# Altium schematic sheet Log and Anti-Log.SchDoc
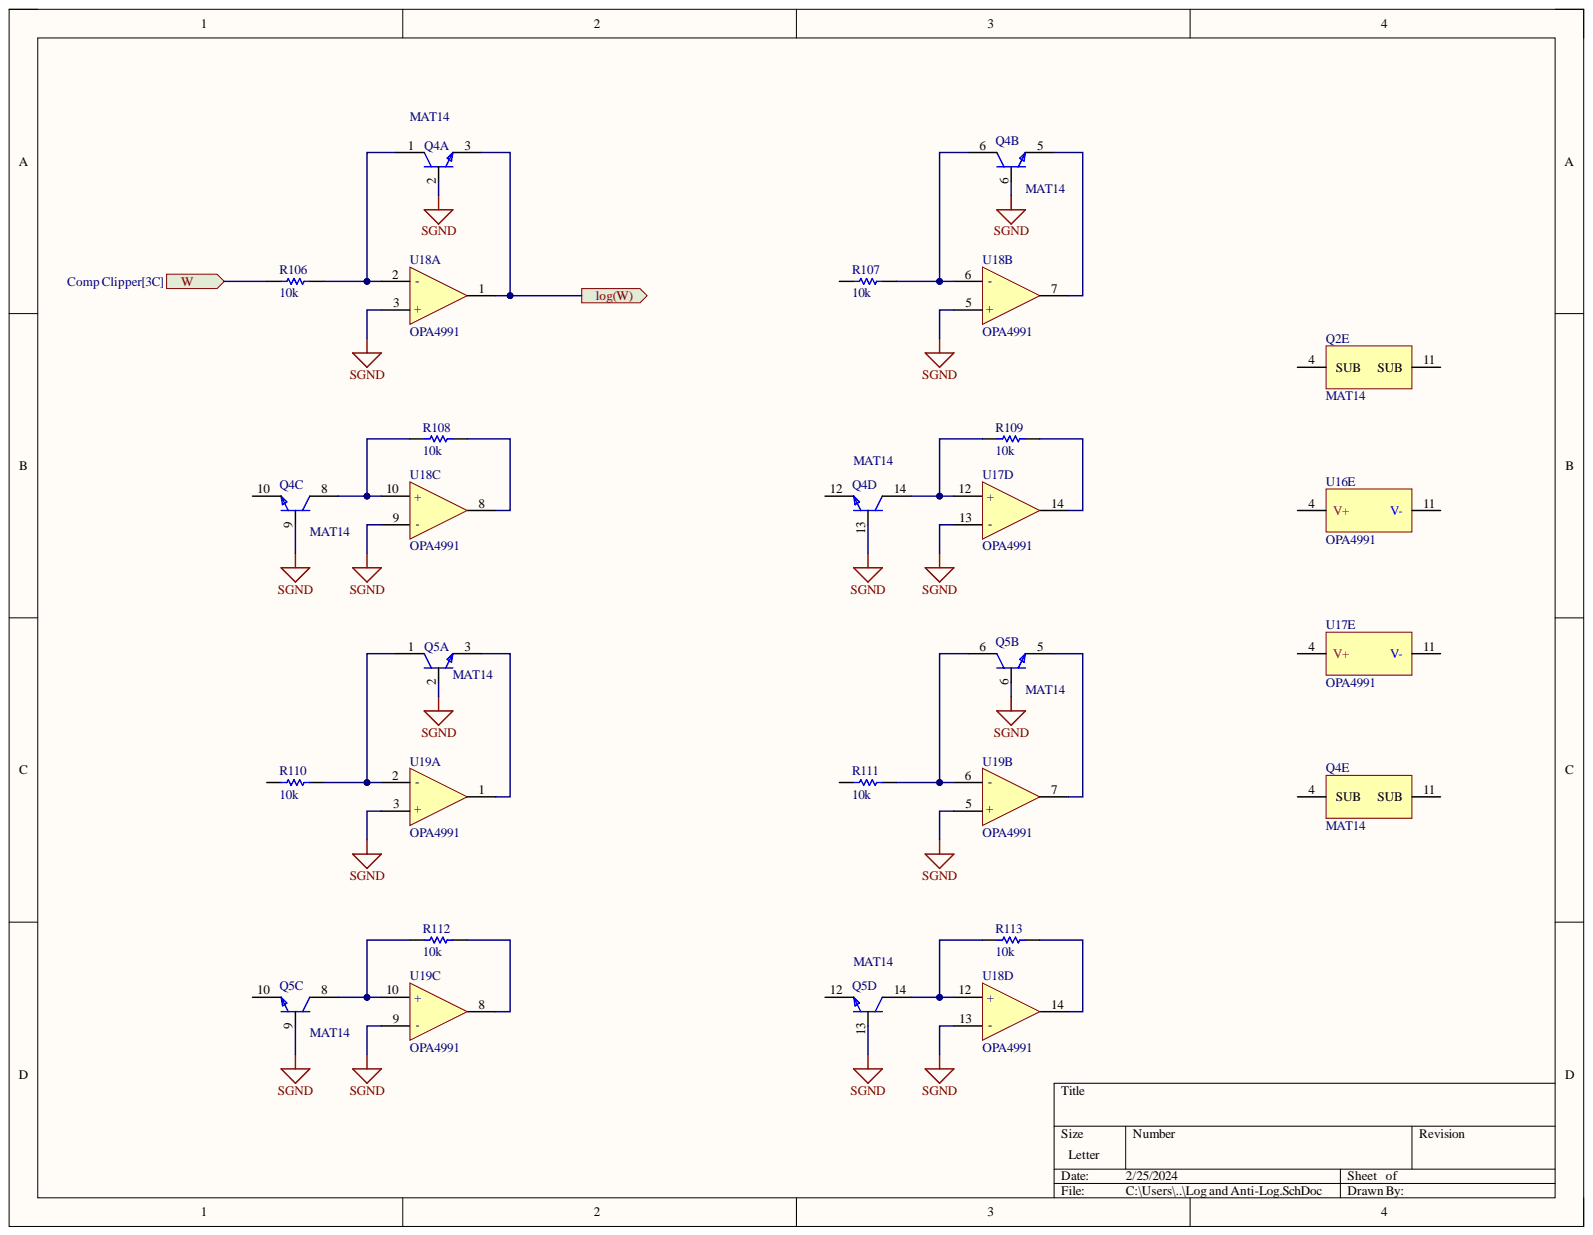
[diagram p0: Log and Anti-Log.SchDoc]

## Parts
Q2  MAT14  [FP-R-14-IPC_B]  (part E)
Q4  MAT14  [FP-R-14-IPC_B]  (parts A,B,C,D,E)
Q5  MAT14  [FP-R-14-IPC_B]  (parts A,B,C,D)
R106  value 10k  (hidden comment: Resistor)
R107  value 10k  (hidden comment: Resistor)
R108  value 10k  (hidden comment: Resistor)
R109  value 10k  (hidden comment: Resistor)
R110  value 10k  (hidden comment: Resistor)
R111  value 10k  (hidden comment: Resistor)
R112  value 10k  (hidden comment: Resistor)
R113  value 10k  (hidden comment: Resistor)
U16  OPA4991  [FP-D0014A-IPC_B]  (part E)
U17  OPA4991  [FP-D0014A-IPC_B]  (parts D,E)
U18  OPA4991  [FP-D0014A-IPC_B]  (parts A,B,C,D)
U19  OPA4991  [FP-D0014A-IPC_B]  (parts A,B,C)

## Ports
log(W), W

## Nets
SGND: Q4.2 Q4.6 Q4.9 Q4.13 Q5.2 Q5.6 Q5.9 Q5.13 U17.13(-) U18.3(+) U18.5(+) U18.9(-) U18.13(-) U19.3(+) U19.5(+) U19.9(-)
log(W): Q4.3 U18.1 ; port log(W)
W: R106.1 ; port W
NetQ4_1: Q4.1 R106.2 U18.2(-)
NetQ4_5: Q4.5 U18.7
NetQ4_6: Q4.6 R107.2 U18.6(-)
NetQ4_8: Q4.8 R108.1 U18.10(+)
NetQ4_14: Q4.14 R109.1 U17.12(+)
NetQ5_1: Q5.1 R110.2 U19.2(-)
NetQ5_3: Q5.3 U19.1
NetQ5_5: Q5.5 U19.7
NetQ5_6: Q5.6 R111.2 U19.6(-)
NetQ5_8: Q5.8 R112.1 U19.10(+)
NetQ5_14: Q5.14 R113.1 U18.12(+)
NetR108_2: R108.2 U18.8
NetR109_2: R109.2 U17.14
NetR112_2: R112.2 U19.8
NetR113_2: R113.2 U18.14

Not connected: Q2 4(SUB) 11(SUB); Q4 4(SUB) 10 11(SUB) 12; Q5 10 12; R107 1; R110 1; R111 1; U16 4(V+) 11(V-); U17 4(V+) 11(V-)

## Library data of the parts
Q2 Q4 Q5 = MAT14ARZ: TRANS 4NPN 40V 0.03A 14SO; Collector Emitter Saturation Voltage: 60mV; Frequency: 300MHz; Max Collector Current: 30mA; Max Frequency: 1kHz; Number of Elements: 4; Polarity: NPN; Case/Package: SOIC; Collector Base Voltage (VCBO): 40V; Collector Emitter Breakdown Voltage: 40V; Collector Emitter Voltage (VCEO): 40V; Collector-emitter Voltage-Max: 60mV; Component Kind: Standard; Height: 1.5mm; Lead Free: Contains Lead; Length: 8.75mm; Max Operating Temperature: 85°C; Min Operating Temperature: -40°C; Mount: Surface Mount; Number of Pins: 14; Package Quantity: 56; Packaging: Rail/Tube; Radiation Hardening: No; REACH SVHC: No SVHC; RoHS Compliant: Yes; Series: MAT14; Transition Frequency: 300MHz; Width: 4mm; Library Name: DRC.SchLib
R106-R113 = Resistor: SimulationGenericComponent: True; TC1: 0; Component Kind: Standard; Description: Resistor; Library Name: Simulation Generic Components.IntLib; Simulation: R_Resistor_Default; TC2: 0
U16-U19 = OPA4991IDR: 40-V, DUAL 4.5MHZ, RAIL-TO-RAIL; Component Kind: Standard; Library Name: DRC.SchLib
